# Altium schematic sheet Multivibrator.SchDoc
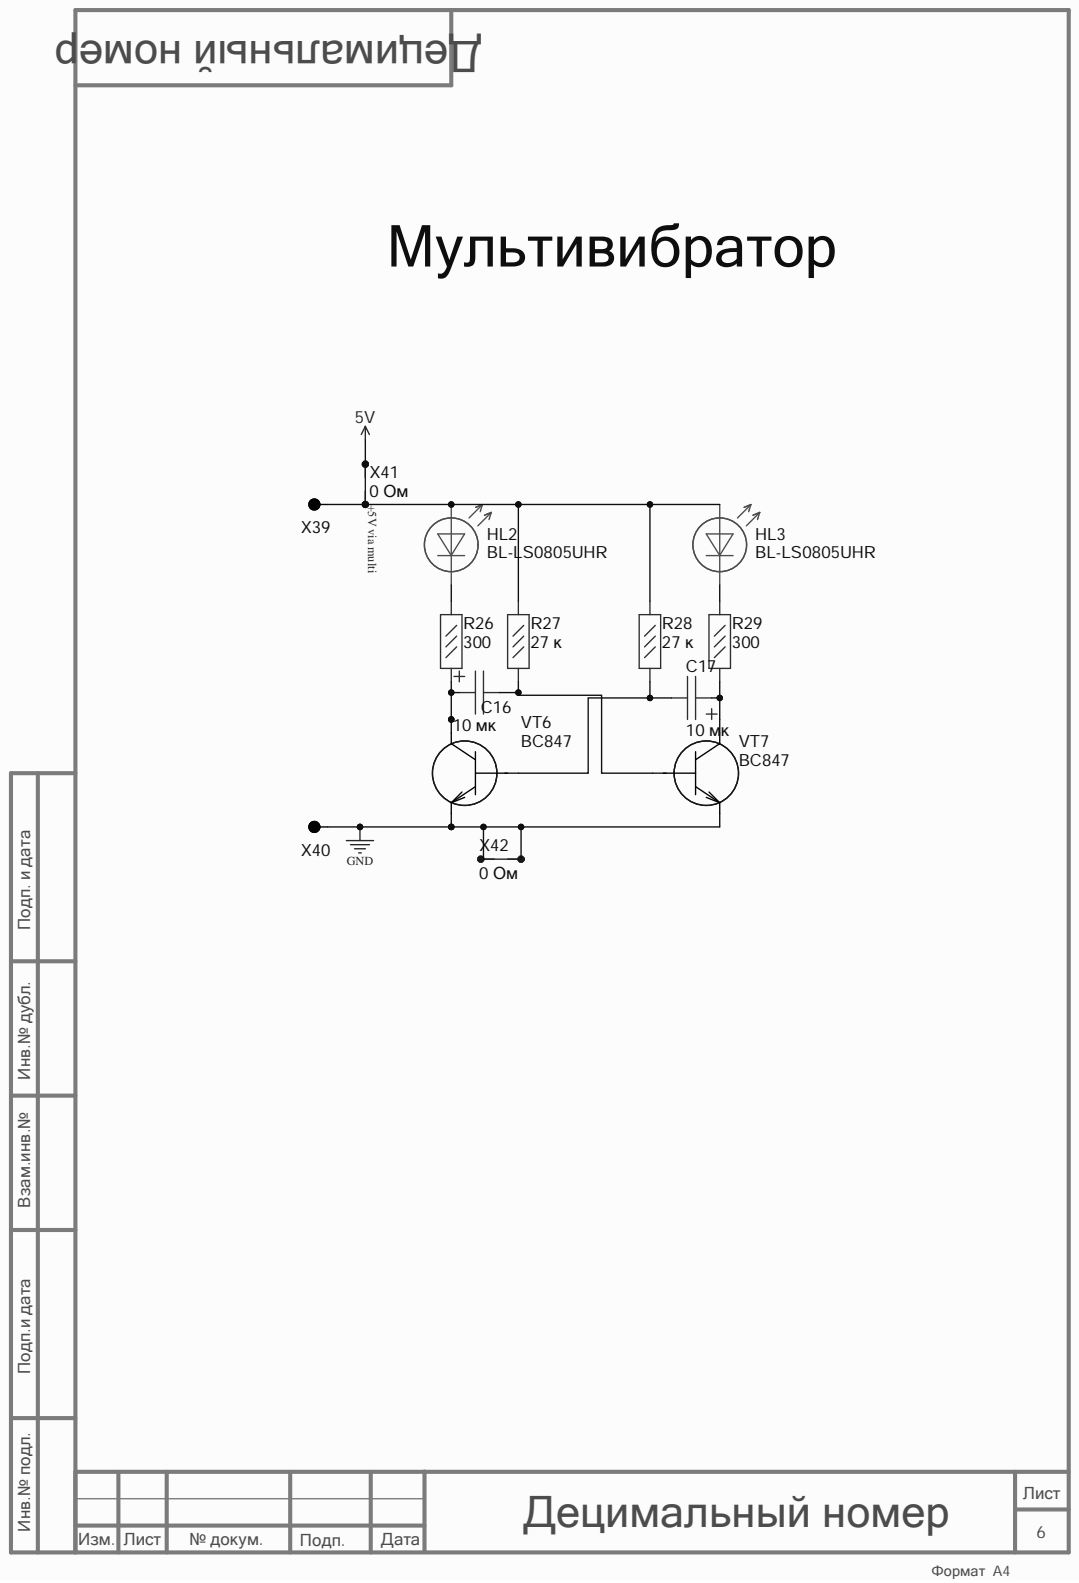
[diagram p0: Multivibrator.SchDoc]

## Parts
C16  10 мк  [B_CASE]
C17  10 мк  [B_CASE]
HL2  BL-LS0805UHR  [LED_0805_RED]
HL3  BL-LS0805UHR  [LED_0805_RED]
R26  300  [R_0805]
R27  27 к  [R_0805]
R28  27 к  [R_0805]
R29  300  [R_0805]
VT6  BC847  [SOT23]
VT7  BC847  [SOT23]
X39  КТ  [PLS-1]  (value not drawn)
X40  КТ  [PLS-1]  (value not drawn)
X41  0 Ом  [R_0805]
X42  0 Ом  [R_0805]

## Nets
GND: VT6.2 VT7.2 X40.1 X42.1
5V: HL2.1 HL3.1 R27.1 R28.1 X39.1 X41.1 X41.2  [also labelled +5V via multi]
NetC16_1: C16.1 R26.2 VT6.3
NetC16_2: C16.2 R27.2 VT7.1
NetC17_1: C17.1 R29.2 VT7.3
NetC17_2: C17.2 R28.2 VT6.1
NetHL2_2: HL2.2 R26.1
NetHL3_2: HL3.2 R29.1

Not connected: X42 2

## Library data of the parts
C16 C17 = TAJB107K004RNJ: CAP TANT 100UF 10% 4V 1411; Manufacturer: AVX Corporation; Database Table Name: Cap_AVX_TAJB$; Value: 100µF; Component Kind: Standard; Component Type: Tantalum Capacitor; ComponentLink1Description: Datasheet; ComponentLink1URL: http://datasheets.avx.com/TAJ.pdf; Library Name: Database_Lib.DbLib; Part Number: TAJB107K004RNJ
R26-R29 = CR0603-FX-1002ELF: RES SMD 10K OHM 1% 1/10W 0603; Manufacturer: Bourns Inc.; Database Table Name: Res_Bourns_CR0603-FX$; Value: 10 к; Component Kind: Standard; Component Type: Resistor; ComponentLink1Description: Datasheet; ComponentLink1URL: https://www.bourns.com/pdfs/chpreztr.pdf; Library Name: Database_Lib.DbLib; Part Number: CR0603-FX-1002ELF
X41 X42 = 0 Ohm (0805): Component Kind: Standard; Library Name: 11.Other.SchLib
HL2 HL3 = BL-LS0805UHR: Component Kind: Standard; Library Name: 4.Diodes.SchLib
VT6 VT7 = BC847: Component Kind: Standard; Library Name: 5.Transistors.SchLib
X39 X40 = КТ: Component Kind: Standard; Library Name: 7.Connectors.SchLib
